# Altium schematic sheet load_cell_amplifiers.SchDoc
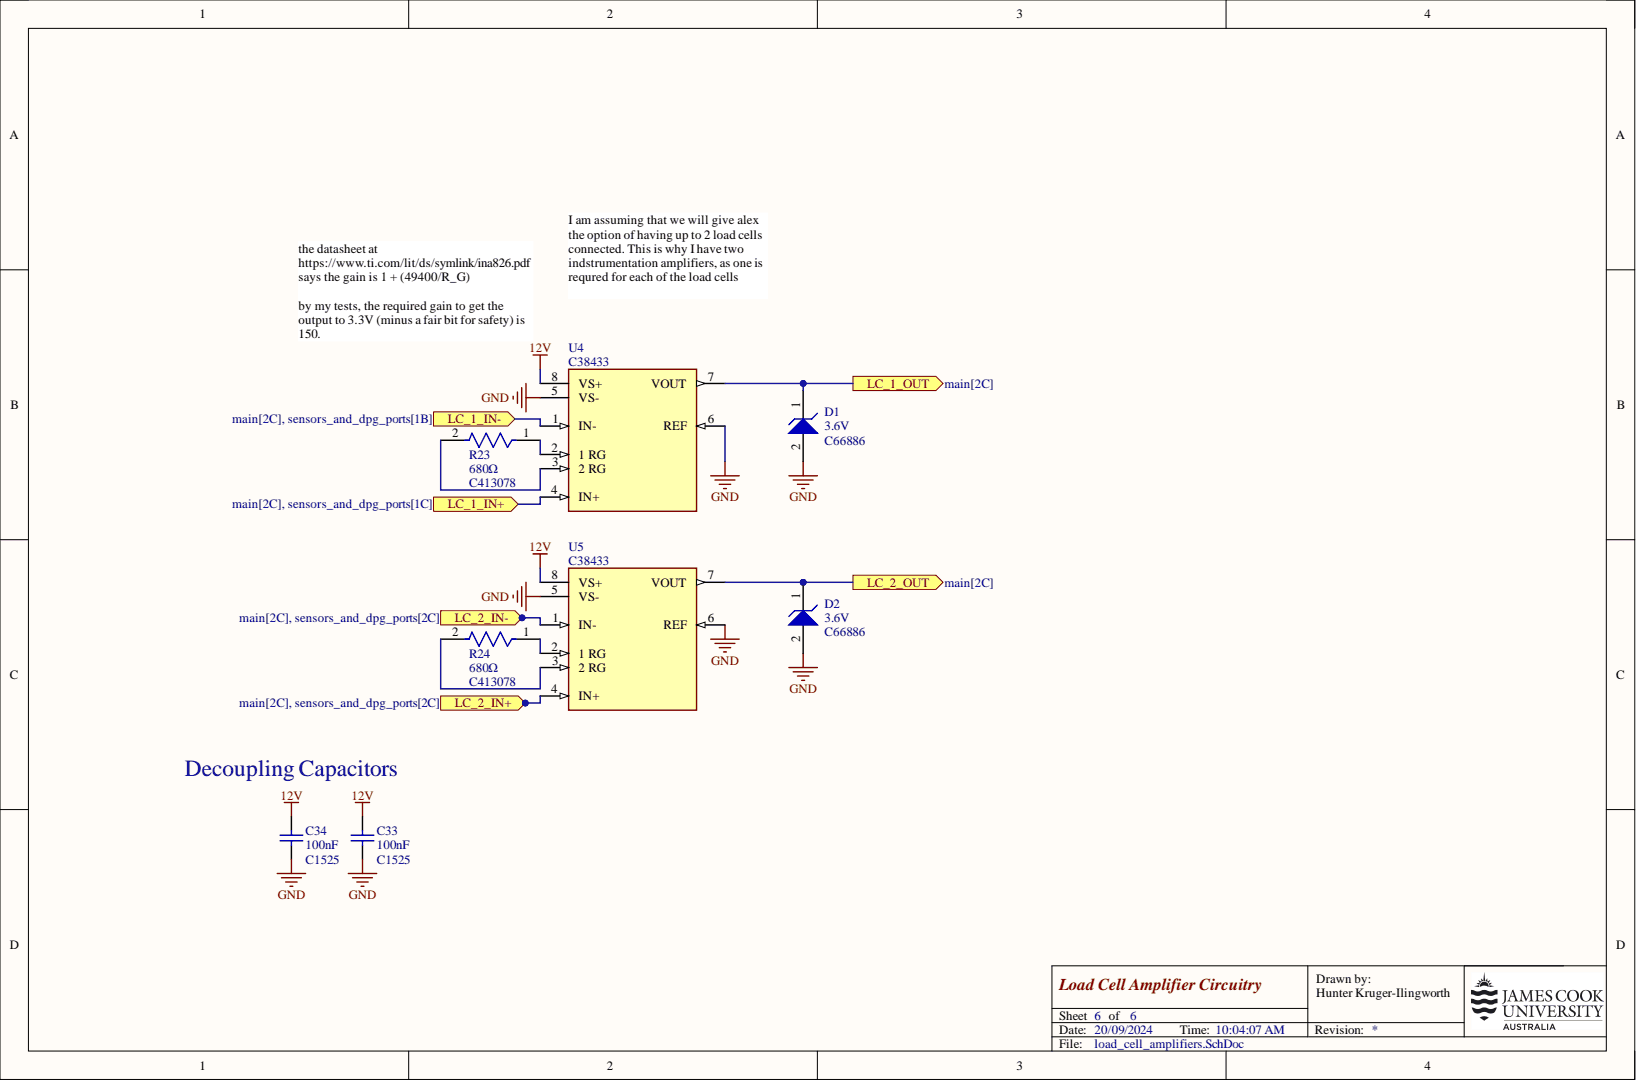
[diagram p0: load_cell_amplifiers.SchDoc]

## Parts
C33  Capacitance: 100nF  JLCPCB Part: C1525  [CAPC1050X55X23NL05T13]  (hidden comment: CL05B104KO5NNNC)
C34  Capacitance: 100nF  JLCPCB Part: C1525  [CAPC1050X55X23NL05T13]  (hidden comment: CL05B104KO5NNNC)
D1  JLCPCB Part: C66886  VZ Typ (V): 3.6V  [ONSC-SOD-123-2-425-04_V]  (hidden comment: MMSZ4685T1G)
D2  JLCPCB Part: C66886  VZ Typ (V): 3.6V  [ONSC-SOD-123-2-425-04_V]  (hidden comment: MMSZ4685T1G)
R23  Resistance: 680Ω  [FP-ERJ2RK-MFG]  (hidden comment: ERJ-2RKF6800X)
R24  Resistance: 680Ω  [FP-ERJ2RK-MFG]  (hidden comment: ERJ-2RKF6800X)
U4  JLC Part Number: C38433  [FP-D0008A-IPC_A]  (hidden comment: INA826AIDR)
U5  JLC Part Number: C38433  [FP-D0008A-IPC_A]  (hidden comment: INA826AIDR)

## Ports
LC_1_IN+, LC_1_IN-, LC_1_OUT, LC_2_IN+, LC_2_IN-, LC_2_OUT

## Nets
12V: C33.2 C34.2 U4.8(VS+) U5.8(VS+)
GND: C33.1 C34.1 D1.2 D2.2 U4.5(VS-) U4.6(REF) U5.5(VS-) U5.6(REF)
LC_1_OUT: D1.1 U4.7(VOUT) ; port LC_1_OUT
LC_2_OUT: D2.1 U5.7(VOUT) ; port LC_2_OUT
NetR23_1: R23.1 U4.2(1_RG)
NetR23_2: R23.2 U4.3(2_RG)
NetR24_1: R24.1 U5.2(1_RG)
NetR24_2: R24.2 U5.3(2_RG)
NetU4_1: U4.1(IN-)
NetU4_4: U4.4(IN+)
NetU5_1: U5.1(IN-)
NetU5_4: U5.4(IN+)

## Library data of the parts
C33 C34 = CMP-00007-3: Chip Capacitor, 100 nF, +/- 10%, 16 V, -55 to 125 degC, 0402 (1005 Metric), RoHS, Tape and Reel; Manufacturer: Samsung Electro-Mechanics; Dielectric: X7R; Rated Voltage: 16V; Case Size/Dimension: 0402; Component Kind: Standard; Datasheet URL: https://datasheet.ciiva.com/29198/458718-da-01-en-vs-kondensator-0402-29198423.pdf; Datasheet Version: Nov-10; Manufacturer URL: http://www.samsungsem.com/global/index.jsp; Tolerance (%): ±10%; Library Name: James Cook University
D1 D2 = CMP-1060-00574-1: Zener Voltage Regulator, 500 mW, 2-Pin SOD-123, Pb-Free, Tape and Reel; Manufacturer: On Semiconductor; P Max (W): 0.5; Component Kind: Standard; ComponentLink1Description: Manufacturer URL; ComponentLink1URL: http://www.onsemi.com/; ComponentLink2Description: Datasheet; ComponentLink2URL: http://www.onsemi.com/pub_link/Collateral/MMSZ4678T1-D.PDF; ComponentLink3Description: Package Specification; ComponentLink3URL: http://www.onsemi.com/pub_link/Collateral/425-04.PDF; DatasheetVersion: Rev. 9; Library Name: Altium Content Vault; Mounting Technology: Surface Mount; PackageDescription: 2-Pin SOD, Body 1.6 x 2.69 mm; PackageReference: SOD-123-2-425-04; PackageVersion: Rev. G, 10/2009; Packing: Tape and Reel; PartNumber: MMSZ4685T1G; RoHS: Pb-Free
R23 R24 = CMP-2002-01166-2: NexarPartId: 12588708; Max Power Dissipation: 100mW; Temperature Coefficient: 100ppm/°C; Tolerance: 1%; Voltage Rating: 50V; Case Code (Imperial): 0402; Case Code (Metric): 1005; Case/Package: 0402; Component Kind: Standard; Composition: Thick Film; Contact Plating: Tin; Depth: 500µm; Features: Automotive AEC-Q200; Height: 350µm; JLCPCB Part: C413078; Lead Free: Lead Free; Length: 1mm; Library Name: Altium Content Vault; Lifecycle Status: Production; Max Operating Temperature: 155°C; Min Operating Temperature: -55°C; Mount: Surface Mount; Number of Pins: 2; Number of Terminations: 2; Packaging: Cut Tape; Power Rating: 100mW; Radiation Hardening: No; REACH SVHC: No SVHC; RoHS: Compliant; Schedule B: 8533210045, 8533210045; Termination: SMD/SMT; Voltage Rating (DC): 50V; Width: 508µm
U4 U5 = CMP-0944-00042-3: IC INST AMP 1 CIRCUIT 8SOIC; -3db Bandwidth: 1MHz; Common Mode Rejection Ratio: 84dB; Input Bias Current: 65nA; Input Offset Voltage (Vos): 150uV; Input Resistance: 100kR; Input Voltage Noise Density: 18nV/sqrt Hz; Max Dual Supply Voltage: 18V; Max Supply Voltage: 36V; Min Dual Supply Voltage: 1.35V; Min Supply Voltage: 2.7V; Nominal Supply Current: 200uA; Number of Amplifiers: 1; Output Current: 16mA; Power Supply Rejection Ratio (PSRR): 100dB; Slew Rate: 1V/µs; Voltage Gain: 60dB; Bandwidth: 1MHz; Case/Package: D; Component Kind: Standard; JLCPCB Part: C38433; Lead Free: Lead Free; Library Name: Altium Content Vault; Max Operating Temperature: 125°C; Min Operating Temperature: -40°C; Number of Channels: 1; Number of Circuits: 1; Number of Elements: 1; Number of Pins: 8; Operating Supply Current: 200uA; Output Current per Channel: 16mA; Package Quantity: 1; Packaging: Tape and Reel; Quiescent Current: 200uA; Radiation Hardening: No; REACH SVHC: No SVHC; RoHS Compliant: Yes; Series: -; Supply Current: 200uA
